# Altium schematic sheet 3_3V_Buck.SchDoc
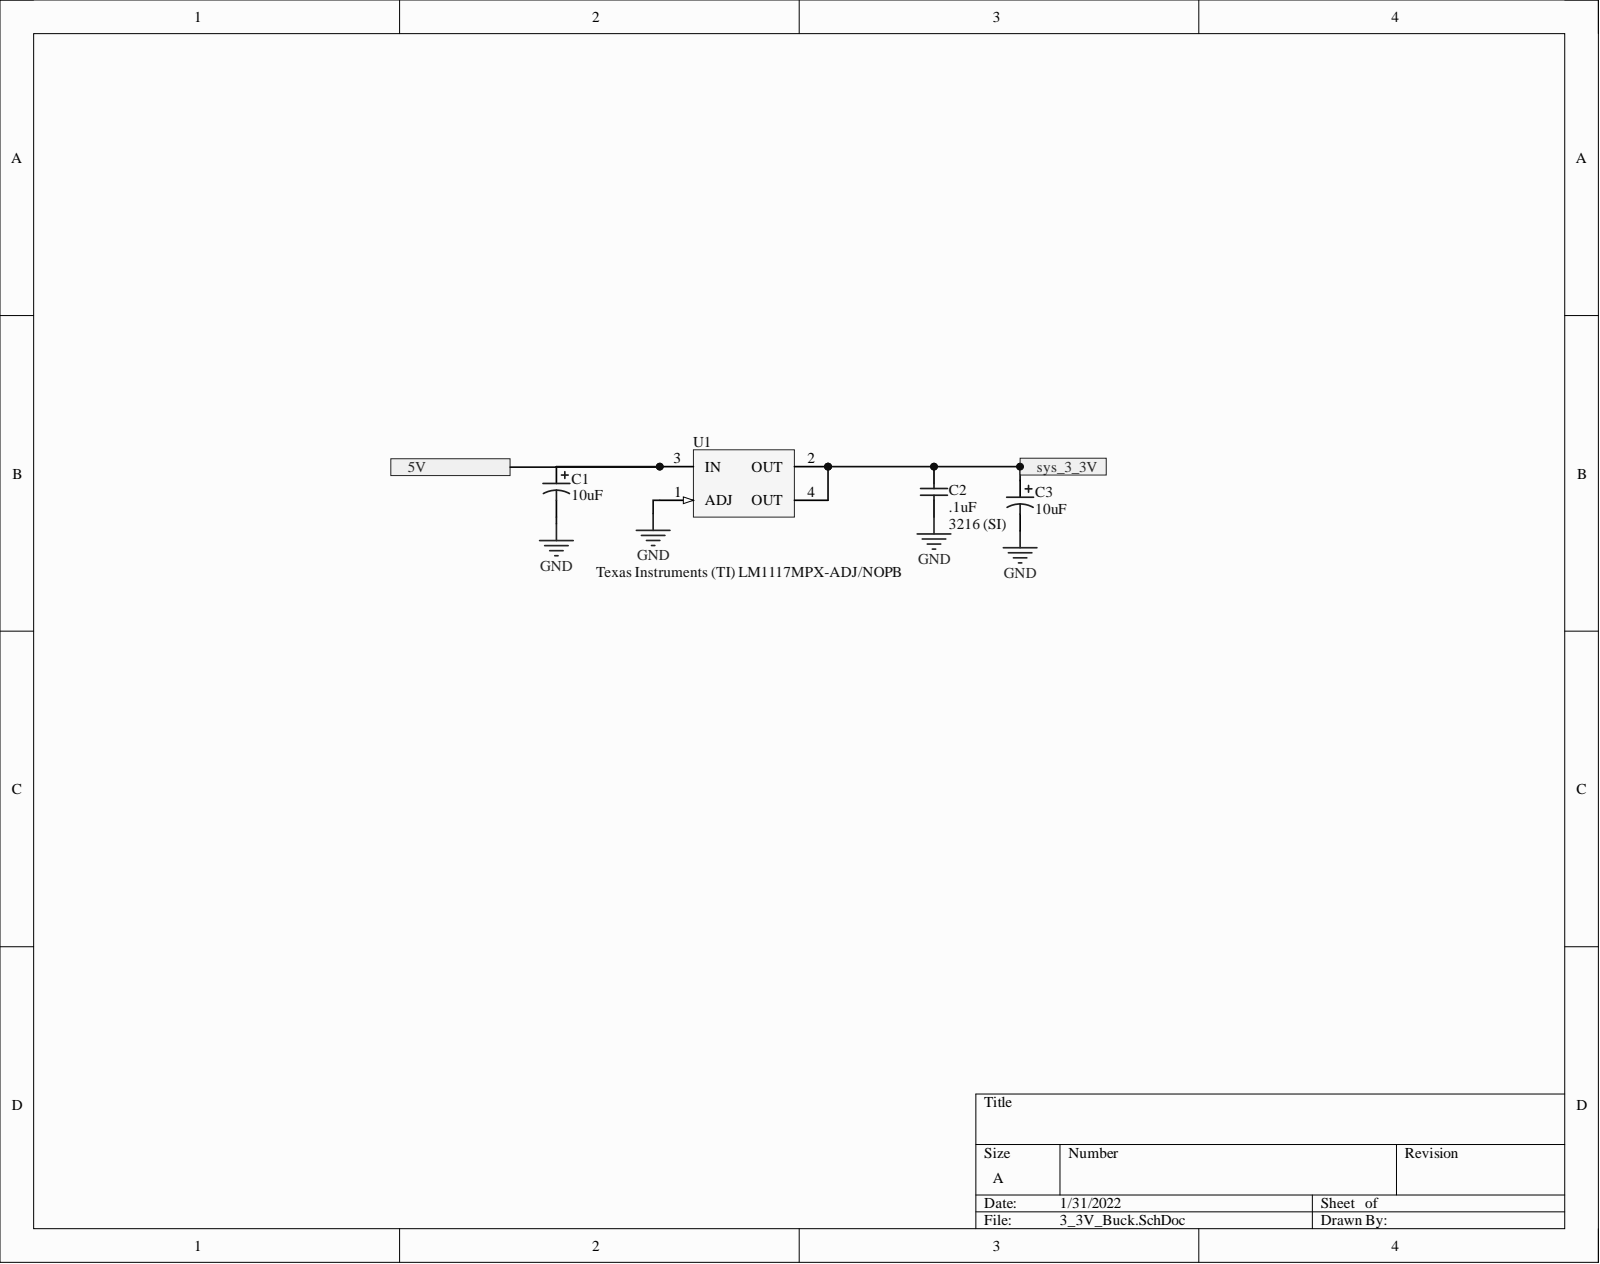
[diagram p0: 3_3V_Buck.SchDoc]

## Parts
C1  10uF  [PCB-imxzc0h3ulzopa7zwnih-1]
C2  .1uF  [CSMD1206GRID25PADB]
C3  10uF  [PCB-imxzc0h3ulzopa7zwnih-1]
U1  Texas Instruments (TI) LM1117MPX-ADJ/NOPB  [SOT223]

## Ports
5V, sys_3_3V

## Nets
GND: C1.2 C2.1 C3.2 U1.1(ADJ)
5V: C1.1 U1.3(IN) ; port 5V
sys_3_3V: C2.2 C3.1 U1.2(OUT) U1.4(OUT) ; port sys_3_3V

## Library data of the parts
C1 C3 = CMP-6a29a3234e15b5ee-2: Capacitor Tantalum Solid 10uF 25V 10% (5.5 X 9mm) Radial 2.5mm 2.5 Ohm 125C Bulk; Manufacturer Part Number: TAP106K025SCS; Capacitance: 10.0 µF; Equivalent Series Resistance (ESR): 2.50 Ω; Lead-Free Status: Lead Free; Capacitance Tolerance: ±10%; Component Kind: Standard; Library Name: CommunityVault; Mounting Style: Through Hole; Number of Pins: 2; Octopart URL: http://octopart.com/tap106k025scs-avx-346; OctopartID: 6a29a3234e15b5ee; REACH SVHC Compliance: No SVHC; RoHS: Compliant; Size-Diameter: 5.59 mm; Size-Height: 8.89 mm
C2 = CMP-150561-3: Cap Ceramic 1uF 25V X7R 20% SMD 1206 125C Plastic T/R; Manufacturing Part Number: ‎C1206C104K5RAC7800‎; Lead-Free Status: R; Voltage Rating (DC): 25.0 V; Capacitance Tolerance: ±20%; Component Kind: Standard; Library Name: CommunityVault; Mounting Style: Surface Mount; Octopart Url: https://octopart.com/c1206c105m3ractu-kemet-8293447; OctopartID: f0ac39d8598d2f6b; Package/Case: 3216 (SI); Packaging: Cut Tape (CT); RoHS: Compliant
U1 = CMP-0062-01644-5: LDO Regulator Pos 1.25V to 13.8V 0.8A 4-Pin(3+Tab) SOT-223 T/R; Current Rating: 800 mA; Dropout Voltage: 1.20 V; Lead-Free Status: Lead Free; Number of Outputs: 1; Output Capacitor Type: Tantalum; Output Voltage: 1.25 V (min); Quiescent Current: 5.00 mA; Component Kind: Standard; Library Name: CommunityVault; Lifecycle Status: Active; Manufacturer Part Number: LM1117MPX-ADJ/NOPB; Mounting Style: Surface Mount; Number of Pins: 3; Number of Regulated Outputs: 1; Octopart Url: http://octopart.com/lm1117mpx-adj%2Fnopb-texas+instruments-24812456; OctopartID: 55309d40ebfe8af7; Output Current: 800 mA; Packaging: Tape & Reel (TR); REACH SVHC Compliance: No SVHC; RoHS: Compliant; Seller Landing  Url: https://octopart-clicks.com/click/track?country=US&ak=8157f166&sig=0fe979a&sid=5489&ppid=24812456&vpid=57539078&ct=offers
